# Altium schematic sheet IMX6UL_ALPHA_ENET1.SchDoc(IMX6UL_ALPHA_ENET1)
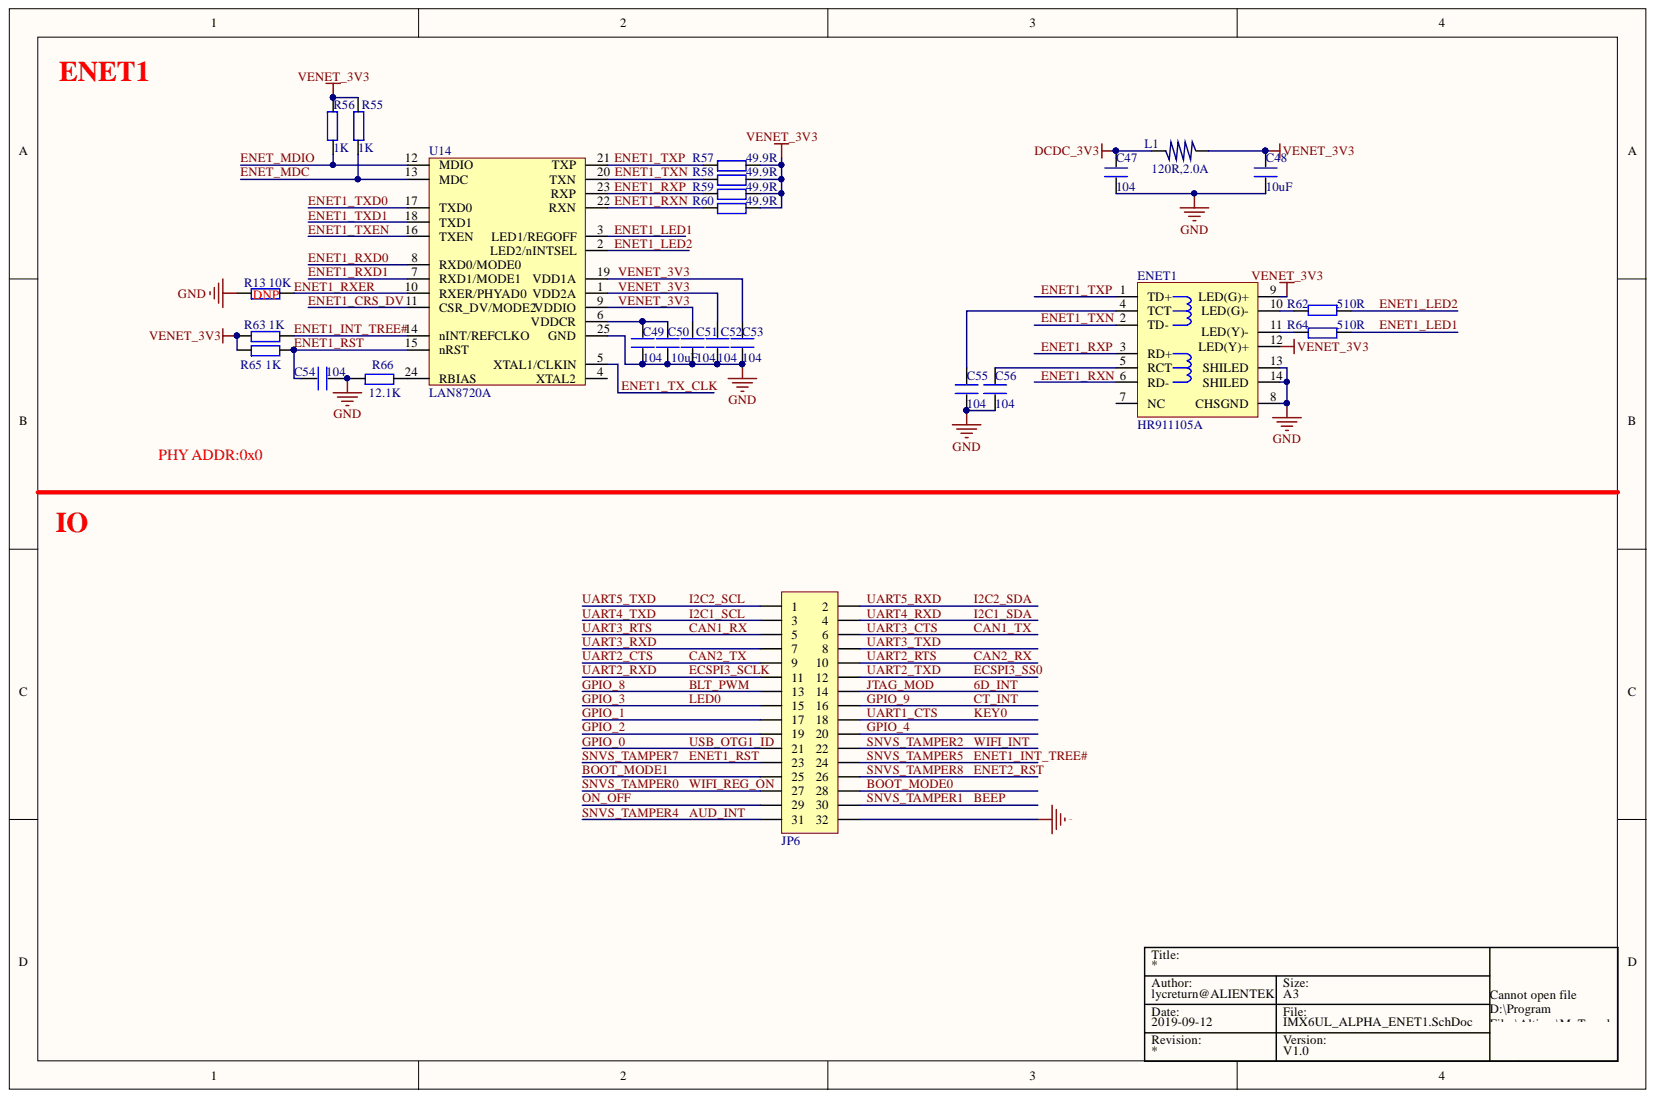
[diagram p0: IMX6UL_ALPHA_ENET1.SchDoc]

## Parts
C47  104  [C 0603]
C48  10uF  [C 0805]
C49  104  [C 0603]
C50  10uF  [C 0805]
C51  104  [C 0603]
C52  104  [C 0603]
C53  104  [C 0603]
C54  104  [C 0603]
C55  104  [C 0603]
C56  104  [C 0603]
ENET1  HR911105A  [RJ-45A12]
JP6  Header 2X11  [HDR-16X2]  (value not drawn)
L1  120R,2.0A  [l 0603]
R13  10K  [R 0603]
R55  1K  [R 0603]
R56  1K  [R 0603]
R57  49.9R  [R 0603]
R58  49.9R  [R 0603]
R59  49.9R  [R 0603]
R60  49.9R  [R 0603]
R62  510R  [R 0603]
R63  1K  [R 0603]
R64  510R  [R 0603]
R65  1K  [R 0603]
R66  12.1K  [R 0603]
U14  LAN8720A  [QFN24]

## Nets
DCDC_3V3: C47.2 L1.2
GND: C47.1 C48.1 C49.1 C50.1 C51.1 C52.1 C53.1 C54.1 C55.1 C56.1 ENET1.8(CHSGND) ENET1.13(SHILED) ENET1.14(SHILED) JP6.32 R13.1 R66.1 U14.25(GND)
6D_INT: JP6.14
AUD_INT: JP6.31
BEEP: JP6.30
BLT_PWM: JP6.13
BOOT_MODE0: JP6.28
BOOT_MODE1: JP6.25
CAN1_RX: JP6.5
CAN1_TX: JP6.6
CAN2_RX: JP6.10
CAN2_TX: JP6.9
CT_INT: JP6.16
ECSPI3_SCLK: JP6.11
ECSPI3_SS0: JP6.12
ENET1_CRS_DV: U14.11(CSR_DV/MODE2)
ENET1_INT_TREE#: JP6.24 R63.2 U14.14(nINT/REFCLKO)
ENET1_LED1: R64.2 U14.3(LED1/REGOFF)
ENET1_LED2: R62.1 U14.2(LED2/nINTSEL)
ENET1_RST: C54.2 JP6.23 R65.2 U14.15(nRST)
ENET1_RXD0: U14.8(RXD0/MODE0)
ENET1_RXD1: U14.7(RXD1/MODE1)
ENET1_RXER: R13.2 U14.10(RXER/PHYAD0)
ENET1_RXN: ENET1.6(RD-) R60.1 U14.22(RXN)
ENET1_RXP: ENET1.3(RD+) R59.1 U14.23(RXP)
ENET1_TX_CLK: U14.5(XTAL1/CLKIN)
ENET1_TXD0: U14.17(TXD0)
ENET1_TXD1: U14.18(TXD1)
ENET1_TXEN: U14.16(TXEN)
ENET1_TXN: ENET1.2(TD-) R58.1 U14.20(TXN)
ENET1_TXP: ENET1.1(TD+) R57.1 U14.21(TXP)
ENET2_RST: JP6.26
ENET_MDC: R55.1 U14.13(MDC)
ENET_MDIO: R56.2 U14.12(MDIO)
GPIO_0: JP6.21
GPIO_1: JP6.17
GPIO_2: JP6.19
GPIO_3: JP6.15
GPIO_4: JP6.20
I2C1_SCL: JP6.3
I2C1_SDA: JP6.4
I2C2_SCL: JP6.1
I2C2_SDA: JP6.2
KEY0: JP6.18
ON_OFF: JP6.29
SNVS_TAMPER0: JP6.27
SNVS_TAMPER2: JP6.22
UART3_RXD: JP6.7
UART3_TXD: JP6.8
VENET_3V3: C48.2 C51.2 C52.2 C53.2 ENET1.9(LED(G)+) ENET1.12(LED(Y)+) L1.1 R55.2 R56.1 R57.2 R58.2 R59.2 R60.2 R63.1 R65.1 U14.1(VDD2A) U14.9(VDDIO) U14.19(VDD1A)
NetC49_2: C49.2 C50.2 U14.6(VDDCR)
NetC55_2: C55.2 ENET1.4(TCT)
NetC56_2: C56.2 ENET1.5(RCT)
NetENET1_10: ENET1.10(LED(G)-) R62.2
NetENET1_11: ENET1.11(LED(Y)-) R64.1
NetR66_2: R66.2 U14.24(RBIAS)

Not connected: ENET1 7(NC); U14 4(XTAL2)

## Library data of the parts
C47-C56 = C: Component Kind: Standard; Library Name: 电阻-电容-电感.SchLib
ENET1 = HR911105A: 带灯以太网接口; 版权归属: 源创客; BOM名称: 带灯以太网接口; Component Kind: Standard; Library Name: 接口类.SchLib; 学习资源: www.ooocke.com
JP6 = Header 16X2: 16*2P接插件; 版权归属: 源创客; BOM名称: 16*2P接插件; Component Kind: Standard; Library Name: 接插件.SchLib; 学习资源: www.ooocke.com
L1 = L: Component Kind: Standard; Library Name: 阿波罗STM32F4&F7底板封装库.IntLib
R13 R55-R60 R62-R66 = R: Component Kind: Standard; Library Name: 电阻-电容-电感.SchLib
U14 = LAN8720: ETH PHY; Component Kind: Standard; Library Name: 阿波罗STM32F4&F7底板封装库.IntLib
